# Altium schematic sheet 12V_24V CONVERTER.SchDoc
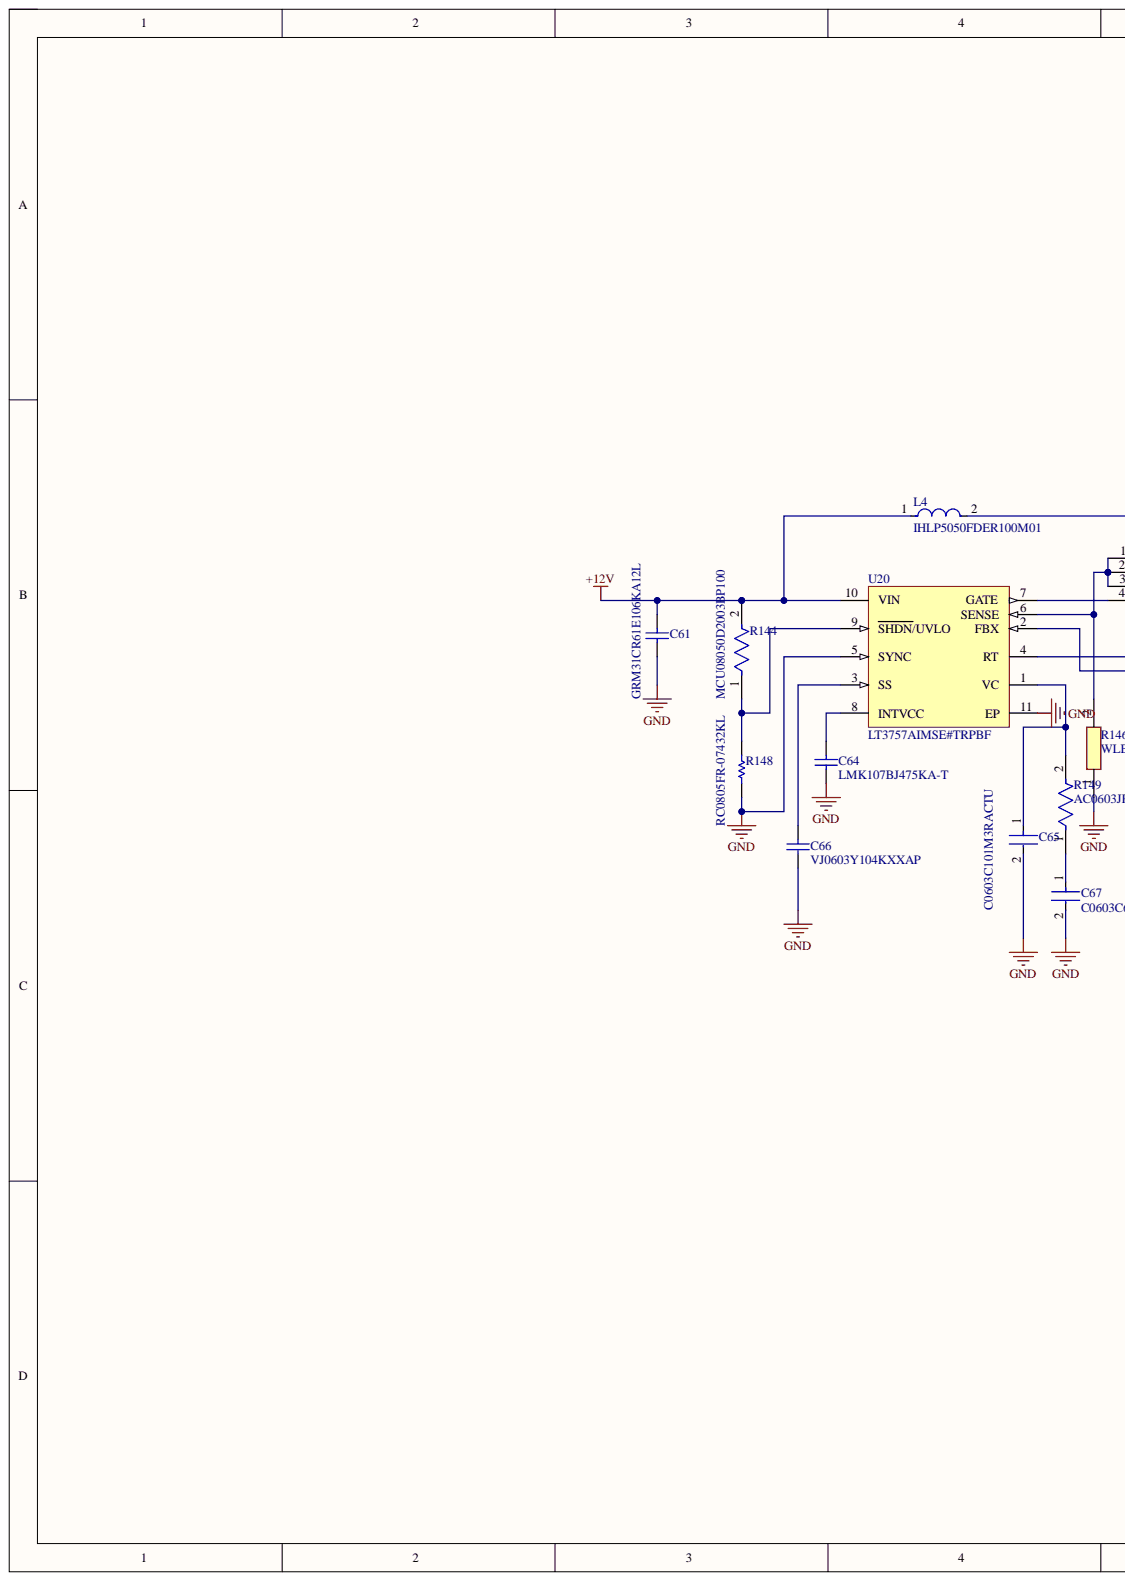
[diagram p0: 12V_24V CONVERTER.SchDoc, left]
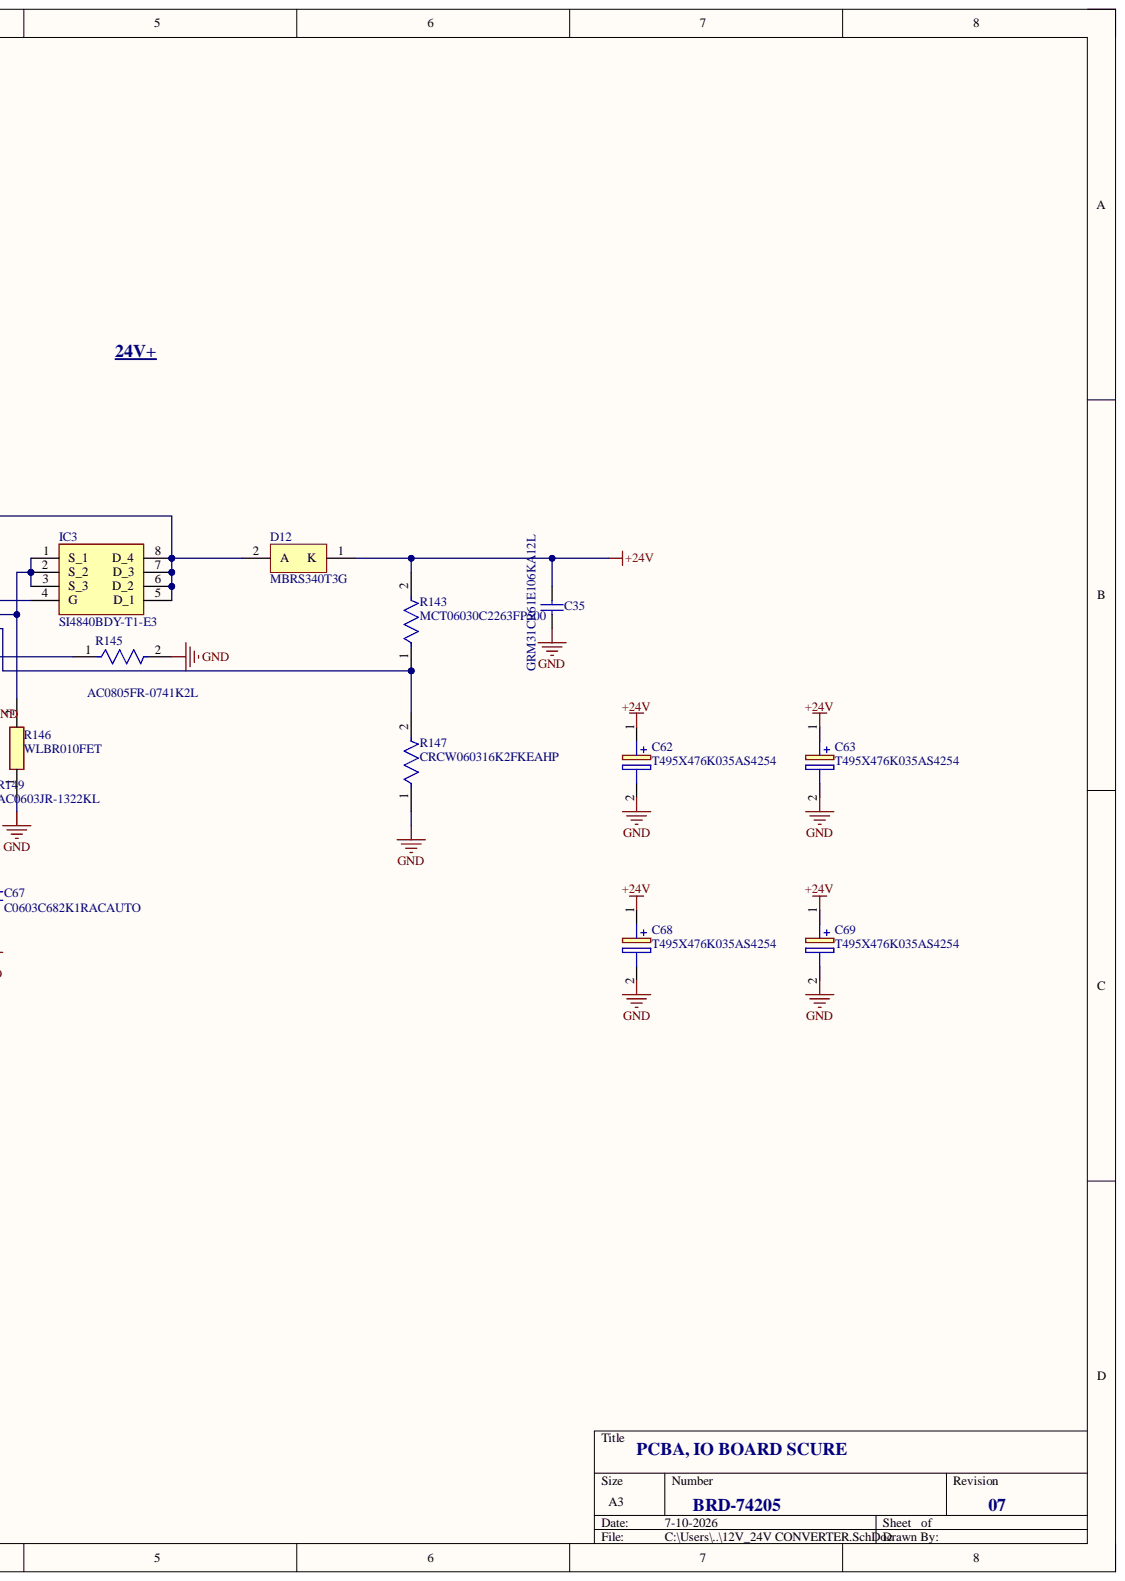
[diagram p1: 12V_24V CONVERTER.SchDoc, right]

## Parts
C35  GRM31CR61E106KA12L  [CAPC3216X180X55ML20T25]
C61  GRM31CR61E106KA12L  [CAPC3216X180X55ML20T25]
C62  T495X476K035AS4254  [CAPPM7343X310N]
C63  T495X476K035AS4254  [CAPPM7343X310N]
C64  LMK107BJ475KA-T  [CAPC1608X90X35NL10T25]
C65  C0603C101M3RACTU  [FP-C0603C-CF-MFG]
C66  VJ0603Y104KXXAP  [CAPC1608X92X38ML15T08]
C67  C0603C682K1RACAUTO  [FP-C0603C-CF-MFG]
C68  T495X476K035AS4254  [CAPPM7343X310N]
C69  T495X476K035AS4254  [CAPPM7343X310N]
D12  MBRS340T3G  [ONSC-SMC-2-403-03_V]
IC3  SI4840BDY-T1-E3  [SOIC127P600X175-8N]
L4  IHLP5050FDER100M01  [FP-IHLP-5050FD-FP_2_945x4-MFG]
R143  MCT06030C2263FP500  [FP-MCT0603-IPC_A]
R144  MCU08050D2003BP100  [FP-MCU0805-IPC_A]
R145  AC0805FR-0741K2L  [FP-AC0805-MFG]
R146  WLBR010FET  [RESAD2020W60L700D330]
R147  CRCW060316K2FKEAHP  [FP-CRCW0603-HPe3-MFG]
R148  RC0805FR-07432KL  [RESC2013X60X35NL10T20]
R149  AC0603JR-1322KL  [FP-AC0603-MFG]
U20  LT3757AIMSE#TRPBF  [LT-MSE-10-EDP_L]

## Nets
+12V: C61.2 L4.1 R144.2 U20.10(VIN)
+24V: C35.2 C62.1 C63.1 C68.1 C69.1 D12.1 R143.2
GND: C35.1 C61.1 C62.2 C63.2 C64.1 C65.2 C66.1 C67.2 C68.2 C69.2 R145.2 R146.1 R147.1 R148.1 U20.5(SYNC) U20.11(EP)
NetC64_2: C64.2 U20.8(INTVCC)
NetC65_1: C65.1 R149.2 U20.1(VC)
NetC66_2: C66.2 U20.3(SS)
NetC67_1: C67.1 R149.1
NetD12_2: D12.2 IC3.5(D_1) IC3.6(D_2) IC3.7(D_3) IC3.8(D_4) L4.2
NetIC3_1: IC3.1(S_1) IC3.2(S_2) IC3.3(S_3) R146.2 U20.6(SENSE)
NetIC3_4: IC3.4(G) U20.7(GATE)
NetR143_1: R143.1 R147.2 U20.2(FBX)
NetR144_1: R144.1 R148.2 U20.9(SHDN/UVLO)
NetR145_1: R145.1 U20.4(RT)

## Library data of the parts
C35 C61 = CMP-2000-04947-1: Chip Capacitor, 10 uF, +/- 10%, 25 V, -55 to 85 degC, 1206 (3216 Metric), RoHS, Tape and Reel; Manufacturer: Murata; Brand: MurataElectronics; Capacitance: 10uF; Case Height: 1.6mm; Case Length: 3.2mm; Dielectric: X5R; Type: GeneralPurposeMLCC; Voltage Rating DC: 25V; Case Code - in: 1206; Case Code - mm: 3216; Case Width: 1.6mm; Component Kind: Standard; Datasheet URL: https://datasheet.ciiva.com/11786/0900766b80ef921d-11786983.pdf; Datasheet Version: Sep-13; Factory Pack Quantity: 2000; Library Name: Altium Content Vault; Manufacturer URL: http://www.murata.com/; Maximum Operating Temperature: +85C; Minimum Operating Temperature: -55C; Package / Case: 1206(3216metric); Package Description: 2-Pin Surface Mount Device, Body 3.2 x 1.6 mm; Package Reference: 1206; Packaging: Reel; Product: GeneralTypeMLCCs; Product Category: MultilayerCeramicCapacitorsMLCC-SMD/SMT; RoHS: Details; Series: GRM; Termination Style: SMD/SMT; Tolerance: 10%
C62 C63 C68 C69 = T495X476K035AS4254: Capacitor Polarised; Manufacturer_Name: Yageo Group; Component Kind: Standard; Datasheet Link: http://www.kemet.com/Lists/ProductCatalog/Attachments/261/KEM_T2009_T495.pdf; Height: 3.1mm; Manufacturer_Part_Number: T495X476K035AS4254; Mouser Part Number: N/A; Mouser Price/Stock: https://www.mouser.co.uk/ProductDetail/KEMET/T495X476K035AS4254?qs=hkbal7gjZMxVRpl%252BG53GxQ%3D%3D
C64 = CMP-2000-05446-2: None; Capacitance: 4.7uF; Dielectric: X5R; Dielectric Material: Ceramic; Tolerance: 10%; Voltage Rating: 10V; Case Code (Imperial): 0603; Case Code (Metric): 1608; Case/Package: 0603; Component Kind: Standard; Construction: Flat; Contact Plating: Nickel; Depth: 0.8mm; Halogen Free: Halogen Free; Height: 0.8mm; Lead Free: Lead Free; Length: 1.6mm; Library Name: Altium Content Vault; Max Operating Temperature: 85°C; Min Operating Temperature: -55°C; Mount: Surface Mount; Number of Pins: 2; Package Quantity: 1; Packaging: Tape and Reel; Radiation Hardening: No; RoHS Compliant: Yes; Series: M; Simulation: LMK107BJ475_A-T; Termination: SMD/SMT; Thickness: 0.035inch; Voltage Rating (DC): 10V; Width: 0.031inch
C65 = CMP-03020-010646-1: C0603 100 pF X7R 30ppm/°C 20.00% 25 V; Manufacturer: KEMET; Automotive Grade: Grade 1; Capacitance: 100 pF; Material: Ceramic; Tolerance: 0.2; Value: 100 pF; Voltage Rating: 25 V; Automotive: Yes; Category: Cap; Component Kind: Standard; ComponentLink2Description: Datasheet; ComponentLink2URL: https://api.kemet.com/component-edge/download/datasheet/C0603C100J5GACAUTO.pdf; Device Class L1: Passive Components; Device Class L2: Capacitors; Device Class L3: Ceramic Capacitors; Height: 0.87mm; Lead Free: Yes; Library Name: Altium Content Vault; Manufacturer Part Number: C0603C101M3RACTU; Octopart: https://octopart.com/c0603c101m3ractu-kemet-88368365; Package: C0603C-CF; RoHS: TRUE; Temperature: -55°C to +125°C; Temperature Characteristic: X7R; Temperature Coefficient: 30ppm/°C; Temperature Range High: +125°C; Temperature Range Low: -55°C
C66 = CMP-2006-01166-1: Manufacturer: Vitramon; Component Kind: Standard; Library Name: Altium Content Vault; Part Number: VJ0603Y104KXXAP
C67 = CMP-03020-007247-1: CAP CER 6800PF 100V X7R 0603; Manufacturer: KEMET; Automotive Grade: Grade 1; Capacitance: 6,800 pF; Material: Ceramic; Temperature Coefficient: 30ppm/°C; Tolerance: 0.1; Value: 6,800 pF; Automotive: Yes; Category: Cap; Component Kind: Standard; ComponentLink2Description: Datasheet; ComponentLink2URL: https://api.kemet.com/component-edge/download/datasheet/C0603C100J5GACAUTO.pdf; Device Class L1: Passive Components; Device Class L2: Capacitors; Device Class L3: Ceramic Capacitors; Digikey Description: CAP CER 6800PF 100V X7R 0603; Height: 0.87mm; Lead Free: Yes; Library Name: Altium Content Vault; Manufacturer Part Number: C0603C682K1RACAUTO; Mouser Description: Cap Ceramic 0.0068uF 100V X7R 10% SMD 0603 125°C Paper T/R; Octopart: https://octopart.com/c0603c682k1racauto-kemet-84841098; Package: C0603C-CF; RoHS: TRUE; Temperature: -55°C to +125°C; Temperature Characteristic: X7R; Temperature Range High: +125°C; Temperature Range Low: -55°C; Voltage Rating: 100 V
D12 = CMP-1055-00380-1: Schottky Power Rectifier, 2-Pin SMC, Pb-Free, Tape and Reel; Manufacturer: On Semiconductor; Configuration: Single; IFSM Max (A): 80; Component Kind: Standard; ComponentLink1Description: Manufacturer URL; ComponentLink1URL: http://www.onsemi.com/; ComponentLink2Description: Datasheet; ComponentLink2URL: http://www.onsemi.cn/pub_link/Collateral/MBRS340T3-D.PDF; ComponentLink3Description: Package Specification; ComponentLink3URL: http://www.onsemi.com/pub_link/Collateral/403-03.PDF; DatasheetVersion: Rev. 11; Library Name: Altium Content Vault; Mounting Technology: Surface Mount; PackageDescription: 2-Pin Small Molded Package, J-Bend Lead, Body 5.845 x 6.855 mm; PackageReference: SMC-2-403-03; PackageVersion: Rev. E, 08/2005; Packing: Tape and Reel; PartNumber: MBRS340T3G; RoHS: TRUE
IC3 = SI4840BDY-T1-E3: Integrated Circuit; Manufacturer_Name: Vishay; Arrow Part Number: SI4840BDY-T1-E3; Arrow Price/Stock: https://www.arrow.com/en/products/si4840bdy-t1-e3/vishay?region=nac; Component Kind: Standard; Datasheet Link: https://componentsearchengine.com/Datasheets/2/SI4840BDY-T1-E3.pdf; Height: 1.75mm; Library Name: SamacSys.SchLib; Manufacturer_Part_Number: SI4840BDY-T1-E3; Mouser Part Number: 781-SI4840BDY-E3; Mouser Price/Stock: https://www.mouser.co.uk/ProductDetail/Vishay-Semiconductors/SI4840BDY-T1-E3?qs=tWM4QuTcS4UqjUU8pH%252B%2F6A%3D%3D
L4 = CMP-02424-000485-1: FIXED IND 10UH 10A 17.2 MOHM SMD; Core Material: Metal; DC Resistance (DCR): 17.2mR; Inductance Tolerance: 20%; Max DC Current: 15.5A; Self Resonant Frequency: 5MHz; Shielding: Shielded; Test Frequency: 100kHz; Case/Package: 5050; Component Kind: Standard; Contact Plating: Tin; Current Rating: 10A; Depth: 12.9mm; Height: 6.5mm; Height - Seated (Max): 0.256inch; Inductance: 10uH; Lead Free: Lead Free; Length: 13.7mm; Library Name: Altium Content Vault; Max Operating Temperature: 125°C; Military Standard: Not; Min Operating Temperature: -55°C; Mount: Surface Mount; Number of Pins: 2; Package Quantity: 250; Packaging: Tape and Reel; Radiation Hardening: No; RMS Current (Irms): 10A; Saturation Current: 15.5A; Termination: SMD/SMT; Tolerance: 20%; Width: 0.508inch
R143 = CMP-02407-010257-1: RES SMD 100 OHM 1% 1/8W 0603; Max Power Dissipation: 125mW; Resistance: 226kR; Temperature Coefficient: 50ppm/°C; Case/Package: 0603; Component Kind: Standard; Composition: Thin Film; Contact Plating: Tin; Height: 0.022inch; Lead Free: Lead Free; Length: 0.061inch; Library Name: Altium Content Vault; Max Operating Temperature: 155°C; Min Operating Temperature: -55°C; Number of Terminations: 2; Package Quantity: 5000; Packaging: Tape and Reel; Radiation Hardening: No; Tolerance: 1%; Width: 0.033inch
R144 = CMP-02407-012110-1: RES 1.05K OHM 0.5% 1/5W 0805; Resistance: 200kR; Temperature Coefficient: 25ppm/°C; Tolerance: 0.1%; Voltage Rating (DC): 100V; Case/Package: 0805; Component Kind: Standard; Construction: Rectangular; Contact Plating: Tin; Depth: 1.25mm; Lead Free: Lead Free; Length: 2mm; Library Name: Altium Content Vault; Max Operating Temperature: 125°C; Military Standard: Not; Min Operating Temperature: -55°C; Packaging: Tape and Reel; Radiation Hardening: No; Resistor Type: Thin Film; RoHS Compliant: Yes; Width: 1.25mm
R145 = CMP-03412-037482-1: Res SMD 41.2K Ohm 1% 1/8W 0805; Manufacturer: Yageo; Automotive Grade: Grade 0; Resistance: 41.2KΩ; Tolerance: 0.01; Value: 41.2KΩ; Voltage Rating: 150V; Automotive: Yes; Category: Res; Component Kind: Standard; ComponentLink2Description: Datasheet; ComponentLink2URL: http://www.yageo.com/documents/recent/PYu-AC_51_RoHS_L_6.pdf; Composition: Thick Film; Device Class L1: Passive Components; Device Class L2: Resistors; Device Class L3: Chip SMD Resistors; Digikey Description: Res SMD 41.2K Ohm 1% 1/8W 0805; Footprint Url: http://www.yageo.com/exep/pages/download/literatures/PYu-R_Mount_10.pdf; Height: 0.6mm; Ipc Land Pattern Name: RESC200125X50; Lead Free: Yes; Library Name: Altium Content Vault; Manufacturer Part Number: AC0805FR-0741K2L; Octopart: https://octopart.com/ac0805fr-0741k2l-yageo-71367723; Package: AC0805; Power Rating: 1/8W; RoHS: TRUE; Series: AC; Temperature: -55°C to +155°C; Temperature Range High: +155°C; Temperature Range Low: -55°C
R146 = WLBR010FET: Resistor; Manufacturer_Name: Ohmite; Arrow Part Number: WLBR010FET; Arrow Price/Stock: https://www.arrow.com/en/products/wlbr010fet/ohmite?utm_currency=USD&region=nac; Component Kind: Standard; Datasheet Link: https://datasheet.datasheetarchive.com/originals/distributors/Datasheets-DGA8/3138959.pdf; Manufacturer_Part_Number: WLBR010FET; Mouser Part Number: 588-WLBR010FET; Mouser Price/Stock: https://www.mouser.co.uk/ProductDetail/Ohmite/WLBR010FET?qs=xbi1O6BJQnjJkpe0PLP6fg%3D%3D
R147 = CMP-02407-004224-1: RES Thick Film, 16.2kΩ, 1%, 0.33W, 100ppm/°C, 0603; Max Power Dissipation: 250mW; Resistance: 16.2kR; Case/Package: 0603; Component Kind: Standard; Composition: Thick Film; Features: Automotive AEC-Q200; Height: 0.022inch; Lead Free: Lead Free; Length: 0.063inch; Library Name: Altium Content Vault; Number of Terminations: 2; Package Quantity: 5000; Packaging: Tape and Reel; Temperature Coefficient: 100ppm/°C; Tolerance: 1%; Width: 0.033inch
R148 = CMP-2001-01145-1: Manufacturer: Yageo; Component Kind: Standard; Library Name: Altium Content Vault; Part Number: RC0805FR-07432KL
R149 = CMP-03412-047749-1: RES 22kΩ 5.00% 0.1W 0603; Resistance: 22kR; Tolerance: 5%; Case/Package: 0603; Component Kind: Standard; Library Name: Altium Content Vault; RoHS Compliant: Yes
U20 = CMP-0491-01032-1: Boost, Flyback, SEPIC and Inverting Controller-Improved Load Transient, 10-pin SOP (MSE-10-EDP), -40 to 125 degC, Pb-Free, Tape and Reel; Manufacturer: Linear Technology; Frequency Adjust Range: 100kHz - 1MHz; Number of Outputs: 1; Component Kind: Standard; ComponentLink1Description: Manufacturer URL; ComponentLink1URL: http://www.linear.com/; ComponentLink2Description: Datasheet; ComponentLink2URL: http://cds.linear.com/docs/en/datasheet/3757Afd.pdf; ComponentLink3Description: Package Specification; ComponentLink3URL: http://cds.linear.com/docs/en/packaging/05081664_I_MSE.pdf; DatasheetVersion: Rev. D; Library Name: Altium Content Vault; Mounting Technology: Surface Mount; PackageDescription: 10-Pin Plastic MSOP, Exposed Die Pad, Body 3 x 3 mm, Pitch 0.5 mm; PackageReference: MSE-10; PackageVersion: Rev. I, 05/2013; Packing: Tape and Reel; PartNumber: LT3757AIMSE#TRPBF; RoHS: TRUE
